# Altium schematic sheet Arduino_Shield_2.SchDoc
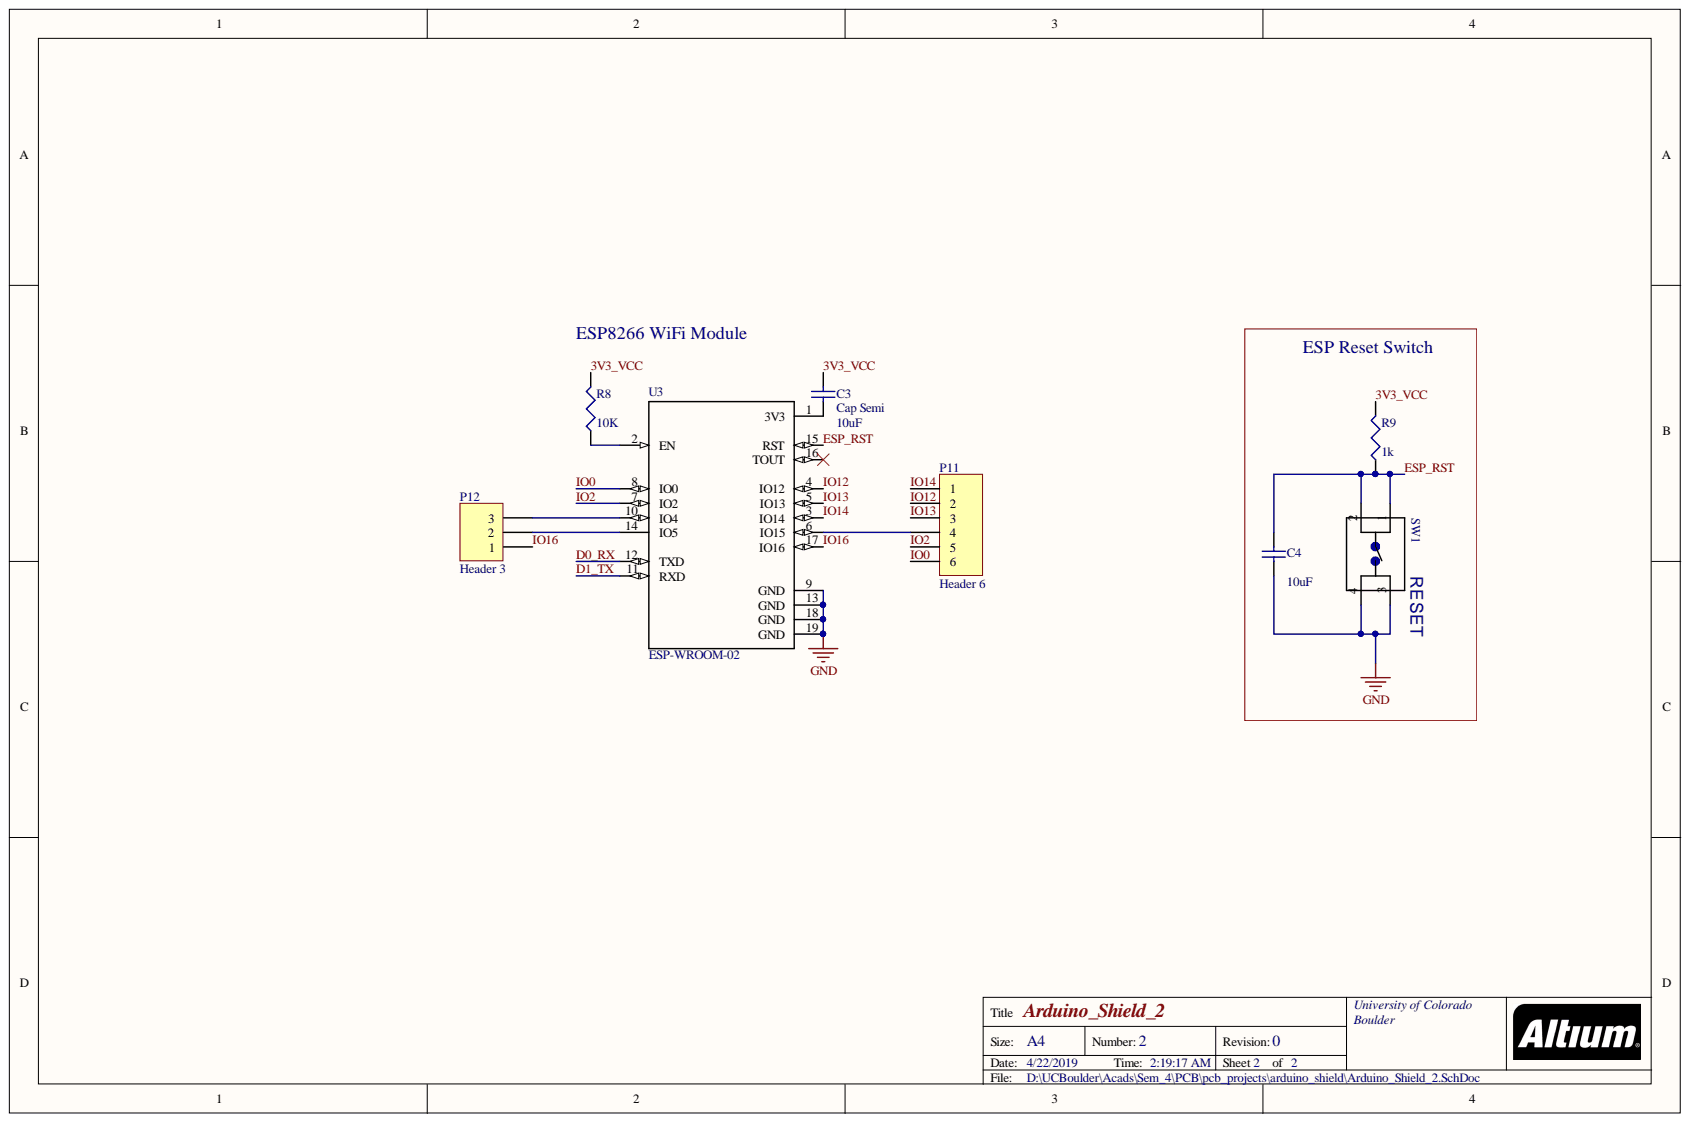
[diagram p0: Arduino_Shield_2.SchDoc]

## Parts
C3  Cap Semi  value 10uF  [C1206]
C4  value 10uF  [C1206]  (hidden comment: Cap Semi)
P11  Header 6  [HDR1X6]
P12  Header 3  [HDR1X3]
R8  value 10K  [C1206]  (hidden comment: Res3)
R9  value 1k  [C1206]  (hidden comment: Res3)
SW1  RESET  [*TE_FSM4JSMA]
U3  ESP-WROOM-02  [*MODULE_ESP-WROOM-02]

## Nets
GND: C4.1 SW1.3 SW1.4 U3.9(GND) U3.13(GND) U3.18(GND) U3.19(GND)
3V3_VCC: C3.2 R8.2 R9.2
D0_RX: U3.12(TXD)
D1_TX: U3.11(RXD)
ESP_RST: C4.2 R9.1 SW1.1 SW1.2 U3.15(RST)
IO0: P11.6 U3.8(IO0)
IO2: P11.5 U3.7(IO2)
IO12: P11.2 U3.4(IO12)
IO13: P11.3 U3.5(IO13)
IO14: P11.1 U3.3(IO14)
IO16: P12.1 U3.17(IO16)
NetC3_1: C3.1 U3.1(3V3)
NetP11_4: P11.4 U3.6(IO15)
NetP12_2: P12.2 U3.14(IO5)
NetP12_3: P12.3 U3.10(IO4)
NetR8_1: R8.1 U3.2(EN)

Not connected: U3 16(TOUT)

## Library data of the parts
C3 C4 = Cap Semi: Capacitor (Semiconductor SIM Model); Component Kind: Standard; DigiKeyPartNo: 1276-1079-1-ND; LatestRevisionDate: 29-May-2009; LatestRevisionNote: IPC-7351 Footprint Added.; Library Name: Miscellaneous Devices.IntLib; PackageDescription: Chip Capacitor; PackageReference: C1206; Published: 8-Jun-2000; Signal Integrity: Cap Semi; Simulation: CAP; Publisher: Altium Limited
P11 = Header 6: Header, 6-Pin; Component Kind: Standard; DigiKeyPartNo: 1212-1179-ND; LatestRevisionDate: 17-Jul-2002; LatestRevisionNote: Re-released for DXP Platform.; Library Name: Miscellaneous Connectors.IntLib; Signal Integrity: Connector; Publisher: Altium Limited
P12 = Header 3: Header, 3-Pin; Component Kind: Standard; DigiKeyPartNo: 1212-1179-ND; LatestRevisionDate: 17-Jul-2002; LatestRevisionNote: Re-released for DXP Platform.; Library Name: Miscellaneous Connectors.IntLib; Signal Integrity: Connector; Publisher: Altium Limited
R8 R9 = Res3: Resistor; Component Kind: Standard; LatestRevisionDate: 29-May-2009; LatestRevisionNote: IPC-7351 Footprint Added.; Library Name: Miscellaneous Devices.IntLib; PackageDescription: Chip Resistor; Published: 8-Jun-2000; Signal Integrity: Res; Simulation: RESISTOR; Publisher: Altium Limited
  R8: DigiKeyPartNo: RMCF1206JT10K0CT-ND; PackageReference: J1-0603
  R9: DigiKeyPartNo: RNCP1206FTD1K00CT-ND; PackageReference: 1206
SW1 = FSM4JSMA: FSM4JSMA=SMT,TACTILE,PB SWITCH; MF: TE Connectivity; Availability: Unavailable; Component Kind: Standard; DigiKeyPartNo: 450-1759-1-ND; EU_RoHS_Compliance: Compliant; Library Name: FSM4JSMA.SchLib; MP: FSM4JSMA; Package: None; Price: None; SnapEDA_Link: https://www.snapeda.com/parts/FSM4JSMA/TE%20Connectivity%20ALCOSWITCH%20Switches/view-part/?ref=snap; TE_purchase_URL: https://www.te.com/usa-en/product-4-1437565-1.html?te_bu=Cor&te_type=disp&te_campaign=seda_glo_cor-seda-global-disp-prtnr-fy19-seda-model-bom-cta_sma-317_1&elqCampaignId=32493
U3 = ESP-WROOM-02: Wi-Fi Module w/ESP8266 802.11b/g/n 20dBm 20x18x3mm; MF: Espressif Systems; Availability: Unavailable; Component Kind: Standard; DigiKeyPartNo: 1904-1021-1-ND; Library Name: ESP-WROOM-02.SchLib; MP: ESP-WROOM-02; Package: SMD-18 Espressif Systems; Price: None; SnapEDA_Link: https://www.snapeda.com/parts/ESP-WROOM-02/Espressif%20Systems/view-part/?ref=snap
